# Altium schematic sheet 555TriangleGeneratorMB-Rev2b.SchDoc(555TriangleGeneratorMB-Rev2b)
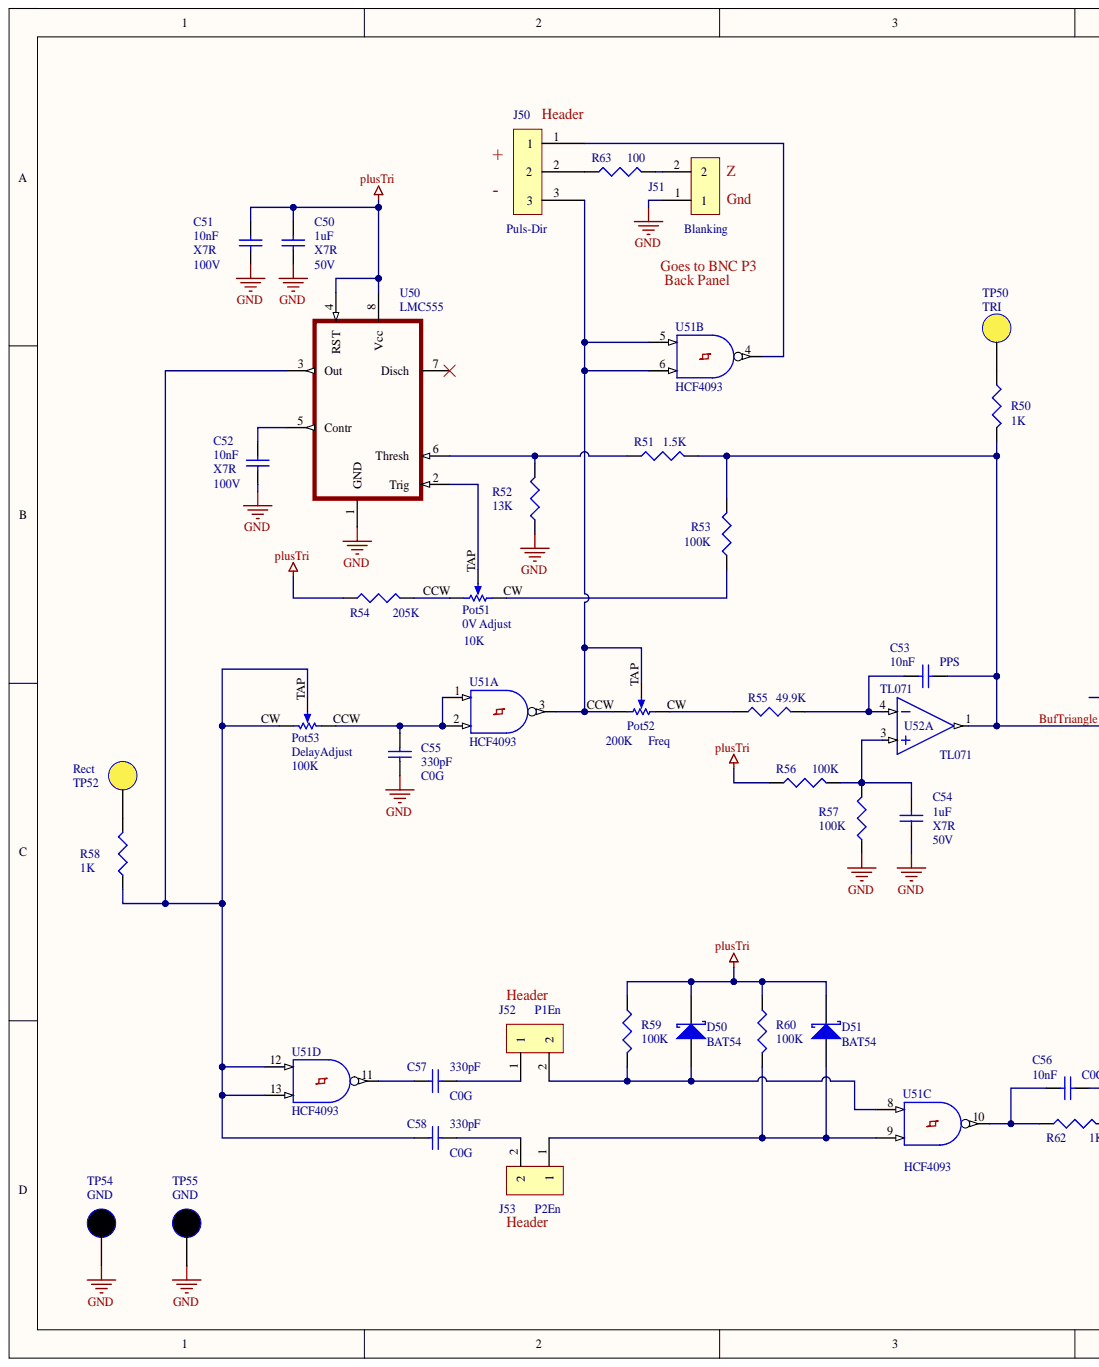
[diagram p0: 555TriangleGeneratorMB-Rev2b.SchDoc, left]
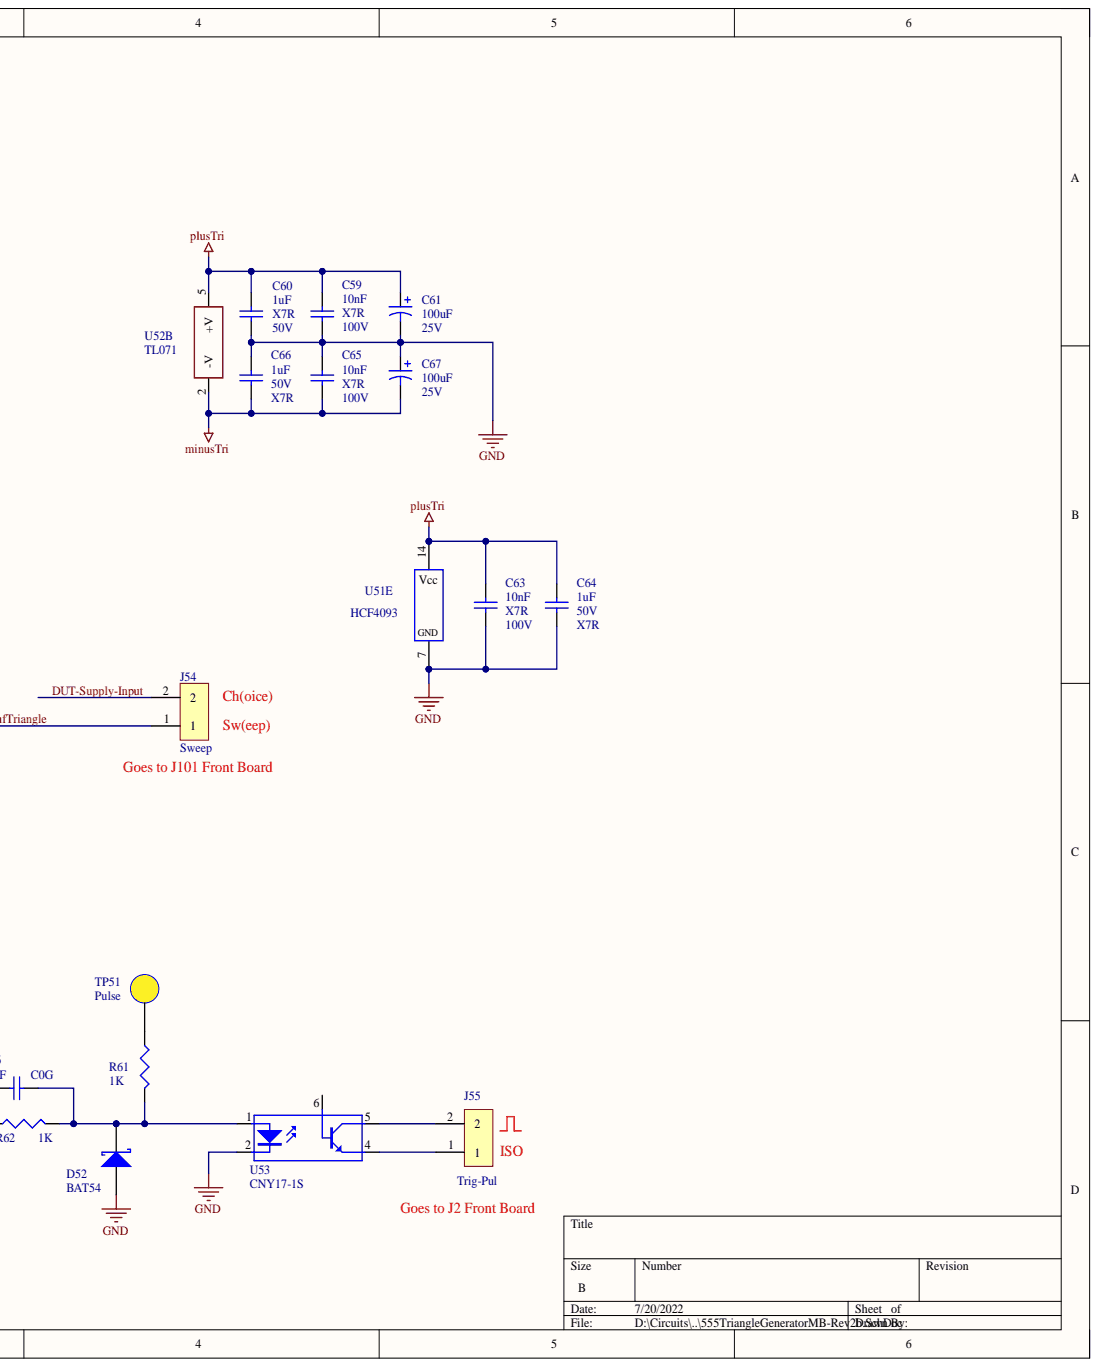
[diagram p1: 555TriangleGeneratorMB-Rev2b.SchDoc, right]

## Parts
C50  1uF  Dielectric: X7R  Voltage: 50V  [CAPC2012M]
C51  10nF  Dielectric: X7R  Voltage: 100V  [CAPC2012M]
C52  10nF  Dielectric: X7R  Voltage: 100V  [CAPC2012M]
C53  value 10nF  Capacitance: 10nF  Dielectric: PPS  [CAPC2012M]  (hidden comment: 1nF)
C54  1uF  Dielectric: X7R  Voltage: 50V  [CAPC2012M]
C55  330pF  Dielectric: C0G  [CAPC2012M]
C56  value 10nF  Capacitance: 10nF  Dielectric: C0G  [CAPC2012M]  (hidden comment: 1nF)
C57  330pF  Dielectric: C0G  [CAPC2012M]
C58  330pF  Dielectric: C0G  [CAPC2012M]
C59  10nF  Dielectric: X7R  Voltage: 100V  [CAPC2012M]
C60  1uF  Dielectric: X7R  Voltage: 50V  [CAPC2012M]
C61  100uF  Voltage: 25V  [CAPPR2-5X11]
C63  10nF  Dielectric: X7R  Voltage: 100V  [CAPC2012M]
C64  1uF  Dielectric: X7R  Voltage: 50V  [CAPC2012M]
C65  10nF  Dielectric: X7R  Voltage: 100V  [CAPC2012M]
C66  1uF  Dielectric: X7R  Voltage: 50V  [CAPC2012M]
C67  100uF  Voltage: 25V  [CAPPR2-5X11]
D50  BAT54  [SOD123]
D51  BAT54  [SOD123]
D52  BAT54  [SOD123]
J50  Puls-Dir  [HDR1X3]
J51  Blanking  [1988956]
J52  P1En  [2X1H0.1LS0.9MMHole]
J53  P2En  [2X1H0.1LS0.9MMHole]
J54  Sweep  [DTA-100-2]
J55  Trig-Pul  [DTA-100-2]
Pot51  0V Adjust  value 10K  [3306P-CCW]
Pot52  Freq  value 200K  [3306P-CCW]
Pot53  DelayAdjust  value 100K  [3306P-CCW]
R50  1K  [RESC2012M]
R51  1.5K  [RESC2012M]
R52  13K  [RESC2012M]
R53  100K  [RESC2012M]
R54  205K  [RESC2012M]
R55  49.9K  [RESC2012M]
R56  100K  [RESC2012M]
R57  100K  [RESC2012M]
R58  1K  [RESC2012M]
R59  100K  [RESC2012M]
R60  100K  [RESC2012M]
R61  1K  [RESC2012M]
R62  1K  [RESC2012M]
R63  100  [RESC2012M]
TP50  TRI  [TP-.038]
TP51  Pulse  [TP-.038]
TP52  Rect  [TP-.038]
TP54  GND  [TP-.038]
TP55  GND  [TP-.038]
U50  LMC555  [SOIC127P600X168_HS-8N]
U51  HCF4093  [SOIC127P600X14-M]  (parts A,B,C,D,E)
U52  TL071  [SOT95P300X130-5M]  (parts A,B)
U53  CNY-17-1S  value CNY17-1S  Manufacturer Part Number: CNY17-1S  [SOIC254P1000X6]

## Nets
GND: C50.2 C51.2 C52.1 C54.2 C55.2 C59.2 C60.2 C61.2 C63.2 C64.2 C65.2 C66.2 C67.1 D52.1 J51.1 R52.2 R57.1 TP54.1 TP55.1 U50.1(GND) U51.7 U53.2
minusTri: C65.1 C66.1 C67.2 U52.2(-V)
plusTri: C50.1 C51.1 C59.1 C60.1 C61.1 C63.1 C64.1 D50.2 D51.2 R54.1 R56.1 R59.1 R60.1 U50.4(RST) U50.8(Vcc) U51.14 U52.5(+V)
BufTriangle: C53.1 J54.1 R50.2 R51.1 R53.1 U52.1
DUT-Supply-Input: J54.2
NetC52_2: C52.2 U50.5(Contr)
NetC53_2: C53.2 R55.2 U52.4
NetC54_1: C54.1 R56.2 R57.2 U52.3
NetC55_1: C55.1 Pot53.CCW U51.1 U51.2
NetC56_1: C56.1 D52.2 R61.2 R62.2 U53.1
NetC56_2: C56.2 R62.1 U51.10
NetC57_1: C57.1 J52.1
NetC57_2: C57.2 U51.11
NetC58_1: C58.1 J53.2
NetC58_2: C58.2 Pot53.CW Pot53.TAP R58.1 U50.3(Out) U51.12 U51.13
NetD50_1: D50.1 J52.2 R59.2 U51.8
NetD51_1: D51.1 J53.1 R60.2 U51.9
NetJ50_1: J50.1 U51.4
NetJ50_2: J50.2 R63.1
NetJ50_3: J50.3 Pot52.CCW Pot52.TAP U51.3 U51.5 U51.6
NetJ51_2: J51.2 R63.2
NetJ55_1: J55.1 U53.4
NetJ55_2: J55.2 U53.5
NetPot51_CCW: Pot51.CCW R54.2
NetPot51_CW: Pot51.CW R53.2
NetPot51_TAP: Pot51.TAP U50.2(Trig)
NetPot52_CW: Pot52.CW R55.1
NetR50_1: R50.1 TP50.1
NetR51_2: R51.2 R52.1 U50.6(Thresh)
NetR58_2: R58.2 TP52.1
NetR61_1: R61.1 TP51.1

Not connected: U50 7(Disch); U53 6

## Library data of the parts
C50 C54 C60 C64 C66 = Cap: CAP CER 1UF 50V X7R 0805; Manufacturer: Samsung; Manufacturer Part Number: CL21B105KBFNNNE; Dielectric Material: Ceramic; Tolerance: 10%; Capacitance: 1uF; Case Code (Imperial): 0805; Case Code (Metric): 2012; Case/Package: 0805; Component Kind: Standard; Construction: Flat; Depth: 1.25mm; Height: 1.25mm; Length: 2mm; Library Name: Miscellaneous Devices.IntLib; Max Operating Temperature: 125°C; Min Operating Temperature: -55°C; Mount: Surface Mount; Number of Pins: 2; Package Quantity: 1; Packaging: Tape and Reel; Radiation Hardening: No; REACH SVHC: No SVHC; RoHS Compliant: Yes; Series: CL; Signal Integrity: Cap; Simulation: CAP; Supplier: Digi-Key; Supplier Part Number: 1276-1029-6-ND; Termination: SMD/SMT; Thickness: 0.053inch; Value: 1uF; Voltage Rating: 50V; Voltage Rating (DC): 50V; Width: 0.049inch
C51 C52 C59 C63 C65 = Cap: CAP CER 10NF 100V X7R 0805; Manufacturer: Samsung; Manufacturer Part Number: CL21B103KCANNNC; Dielectric Material: Ceramic; Dissipation Factor: 2.5%; Tolerance: 10%; Capacitance: 10nF; Case Code (Imperial): 0805; Case Code (Metric): 2012; Case/Package: 0805; Component Kind: Standard; Construction: Flat; Contact Plating: Tin; Depth: 1.25mm; Height: 0.65mm; Length: 2mm; Library Name: Miscellaneous Devices.IntLib; Material: Ceramic; Max Operating Temperature: 125°C; Min Operating Temperature: -55°C; Mount: Surface Mount; Number of Pins: 2; Package Quantity: 1; Packaging: Tape and Reel; Radiation Hardening: No; REACH SVHC: No SVHC; RoHS Compliant: Yes; Series: CL; Signal Integrity: Cap; Simulation: CAP; Supplier: Digi-Key; Supplier Part Number: 1276-1249-6-ND; Termination: SMD/SMT; Thickness: 0.03inch; Value: 10nF; Voltage Rating: 100V; Voltage Rating (DC): 100V; Width: 0.049inch
C53 = Cap: CAP FILM 10NF PPS16V; Manufacturer: Panasonic; Manufacturer Part Number: ECHU1C103JX5; Dielectric Material: Polyphenylene; Dissipation Factor: 0.6%; Insulation Resistance: 3GR; Life (Hours): 500Hour; Material: Ceramic; Tolerance: 5%; Voltage: 16V; Case Code (Imperial): 0805; Case Code (Metric): 2012; Case/Package: 0805; Category: Film Capacitors; Component Kind: Standard; ComponentLink1Description: https://datasheet.ciiva.com/11790/0900766b80eaa63b-11790974.pdf?src-supplier=RSComponents; ComponentLink1URL: https://datasheet.ciiva.com/11790/0900766b80eaa63b-11790974.pdf?src-supplier=RSComponents; ComponentLink2Description: https://datasheet.ciiva.com/46399/101575-46399306.pdf?src-supplier=Element14; ComponentLink2URL: https://datasheet.ciiva.com/46399/101575-46399306.pdf?src-supplier=Element14; ComponentLink3Description: https://datasheet.ciiva.com/14591/70067901-14591819.pdf?src-supplier=Allied+Electronics; ComponentLink3URL: https://datasheet.ciiva.com/14591/70067901-14591819.pdf?src-supplier=Allied+Electronics; ComponentLink4Description: https://datasheet.ciiva.com/16200/abd0000ce13-16200967.pdf?src-supplier=Future+Electronics; ComponentLink4URL: https://datasheet.ciiva.com/16200/abd0000ce13-16200967.pdf?src-supplier=Future+Electronics; ComponentLink5Description: https://datasheet.ciiva.com/30122/21abd0000ce13-30122759.pdf?src-supplier=Onlinecomponents.com; ComponentLink5URL: https://datasheet.ciiva.com/30122/21abd0000ce13-30122759.pdf?src-supplier=Onlinecomponents.com; Construction: Flat; Contact Plating: Copper,Tin,Silver; Depth: 1.25mm; Height: 1.1mm; Height - Seated (Max): 0.051inch; Lead Free: Lead Free; Length: 2mm; Library Name: Miscellaneous Devices.IntLib; Max Operating Temperature: 125°C; Min Operating Temperature: -55°C; Mount: Surface Mount; Number of Pins: 2; Package Quantity: 1; Packaging: Tape and Reel; Pricing 1: 1=0.44000, 10=0.31000, 50=0.23440, 100=0.20410, 500=0.15120, 1000=0.12852 (USD); Radiation Hardening: No; REACH SVHC: No SVHC; RoHS: Yes; RoHS Compliant: Yes; Series: ECHU(X); Signal Integrity: Cap; Simulation: CAP; Stock 1: 49221; Supplier: Digi-Key; Supplier Part Number: PCF1196CT-ND; Termination: SMD/SMT; Voltage Rating: 16V; Voltage Rating (DC): 16V; Width: 1.25mm
C55 C57 C58 = Cap: CAP CER 330PF 50V COG 0805; Manufacturer: KEMET; Manufacturer Part Number: C0805C331K5GACTU; Dielectric Material: Ceramic; Dissipation Factor: 0.1%; Tolerance: 10%; Capacitance: 330pF; Case Code (Imperial): 0805; Case Code (Metric): 2012; Case/Package: 0805; Component Kind: Standard; Depth: 1.25mm; Height: 0.78mm; Lead Free: Lead Free; Lead Pitch: 0.75mm; Length: 2mm; Library Name: Miscellaneous Devices.IntLib; Max Operating Temperature: 125°C; Min Operating Temperature: -55°C; Mount: Surface Mount; Package Quantity: 1; Packaging: Tape and Reel; Radiation Hardening: No; RoHS Compliant: Yes; Series: C; Signal Integrity: Cap; Simulation: CAP; Supplier: Digi-Key; Supplier Part Number: 399-8065-6-ND; Termination: SMD/SMT; Thickness: 0.035inch; Value: 330pF; Voltage Rating: 50V; Voltage Rating (DC): 50V; Width: 0.049inch
C56 = Cap: CAP CER 10NF 100V NP0 0805; Manufacturer: KEMET; Manufacturer Part Number: C0805C103J5GACTU; Dielectric Material: Ceramic; Dissipation Factor: 0.1%; Temperature Coefficient: 30ppm/°C; Tolerance: 5%; Voltage: 50V; Case Code (Imperial): 0805; Case Code (Metric): 2012; Case/Package: 0805; Component Kind: Standard; Construction: Flat; Contact Material: Tin; Contact Plating: Tin,Matte; Depth: 1.25mm; Height: 0.78mm; Lead Free: Lead Free; Lead Pitch: 0.75mm; Length: 2mm; Library Name: Miscellaneous Devices.IntLib; Max Operating Temperature: 125°C; Min Operating Temperature: -55°C; Mount: Surface Mount; Number of Pins: 2; Package Quantity: 1; Packaging: Tape and Reel; Radiation Hardening: No; REACH SVHC: No SVHC; RoHS Compliant: Yes; Series: C; Signal Integrity: Cap; Simulation: CAP; Supplier: Digi-Key; Supplier Part Number: 399-7992-6-ND; Termination: SMD/SMT; Thickness: 0.035inch; Voltage Rating: 50V; Voltage Rating (DC): 50V; Width: 0.049inch
C61 C67 = Cap Pol1: Aluminum Electrolytic Capacitors - Leaded 100uF ±20% 25V Radial, 5x11mm RoHS; Manufacturer: Rubycon; Manufacturer Part Number: 25YXJ100M5X11; Impedance: 400mR; Lead Diameter: 0.5mm; Leakage Current: 3uA; Life (Hours): 5000Hour; Polarity: Polar; Ripple Current: 250mA; Capacitance: 100uF; Case/Package: Radial; Category: Aluminum Electrolytic Capacitors; Component Kind: Standard; ComponentLink1Description: https://datasheet.ciiva.com/11777/0900766b810307a1-11777001.pdf?src-supplier=RSComponents; ComponentLink1URL: https://datasheet.ciiva.com/11777/0900766b810307a1-11777001.pdf?src-supplier=RSComponents; ComponentLink2Description: https://datasheet.ciiva.com/41806/e-yxj-41806041.pdf?src-supplier=Digi-Key; ComponentLink2URL: https://datasheet.ciiva.com/41806/e-yxj-41806041.pdf?src-supplier=Digi-Key; ComponentLink3Description: https://datasheet.ciiva.com/11690/e-yxj-11690904.pdf?src-supplier=Avnet; ComponentLink3URL: https://datasheet.ciiva.com/11690/e-yxj-11690904.pdf?src-supplier=Avnet; Construction: RADIAL; Diameter: 5mm; Height: 11mm; Height - Seated (Max): 0.492inch; Lead Pitch: 2mm; Library Name: Miscellaneous Devices.IntLib; Max Operating Temperature: 105°C; Min Operating Temperature: -40°C; Mount: Through Hole; Package Quantity: 3000; Packaging: Bulk; Pricing 1: 1=0.25000, 10=0.17200, 50=0.10360, 100=0.08740, 500=0.05980, 1000=0.05290, 2500=0.05060, 5000=0.04600 (USD); Radiation Hardening: No; RoHS: Yes; RoHS Compliant: Yes; Series: YXJ; Signal Integrity: Cap Pol; Simulation: CAP; Stock 1: 7789; Supplier: Digi-Key; Supplier Part Number: 1189-2237-ND; Termination: Radial; Tolerance: 20%; Value: 100uF; Voltage Rating: 25V
D50-D52 = Diode-Schottkey-12: SBAT54T1G Series 30 200 mA Surface Mount Schottky Barrier Diode - SOD-123; Manufacturer: ON Semiconductor; Average Rectified Current: 200mA; Element Configuration: Single; Forward Voltage: 520mV; Max Forward Surge Current (Ifsm): 600mA; Max Power Dissipation: 400mW; Max Repetitive Reverse Voltage (Vrrm): 30V; Max Reverse Current: 500nA; Reverse Recovery Time: 5ns; Category: Schottky Diodes; Component Kind: Standard; ComponentLink1Description: http://www.farnell.com/datasheets/1840528.pdf?src-supplier=Farnell; ComponentLink1URL: http://www.farnell.com/datasheets/1840528.pdf?src-supplier=Farnell; ComponentLink2Description: http://www.mouser.com/ds/2/308/BAT54T1-D-108887.pdf?src-supplier=Mouser; ComponentLink2URL: http://www.mouser.com/ds/2/308/BAT54T1-D-108887.pdf?src-supplier=Mouser; ComponentLink3Description: http://www.onsemi.com/pub/Collateral/BAT54T1-D.PDF?src-supplier=Digi-Key; ComponentLink3URL: http://www.onsemi.com/pub/Collateral/BAT54T1-D.PDF?src-supplier=Digi-Key; ComponentLink4Description: http://www.onsemi.com/pub/Collateral/BAT54T1-D.PDF?src-supplier=Avnet; ComponentLink4URL: http://www.onsemi.com/pub/Collateral/BAT54T1-D.PDF?src-supplier=Avnet; Forward Current: 200mA; Lead Free: Lead Free; Library Name: MY_Diodes.SchLib; Manufacturer Part Number: SBAT54T1G; Max Operating Temperature: 125°C; Max Reverse Voltage (DC): 30V; Min Operating Temperature: -55°C; Mount: Surface Mount; Package Quantity: 3000; Packaging: Tape and Reel; Pricing 1: 1=0.48700, 5=0.48700, 10=0.36400, 100=0.22100 (USD); Reverse Voltage (DC): 30V; RoHS: Yes; RoHS Compliant: Yes; Stock 1: 1490; Supplier: Newark; Supplier Part Number: 50AC7280; Value: BAT54
J50 = 3-Pin: HEADER VERT 3 POS 0.1LS; Manufacturer: CUI; Manufacturer Part Number: PH1-03-UA; Current Rating: 1A; Number of Positions: 3; Pitch: 2mm; Value: PH1-03-UA; Voltage Rating: 250V; Category: Connectors; Component Kind: Standard; ComponentLink1Description: https://datasheet.ciiva.com/16500/2ph1-xx-ua-16500546.pdf?src-supplier=Future+Electronics; ComponentLink1URL: https://datasheet.ciiva.com/16500/2ph1-xx-ua-16500546.pdf?src-supplier=Future+Electronics; ComponentLink2Description: https://datasheet.ciiva.com/16396/catpg240-254-16396026.pdf?src-supplier=Future+Electronics; ComponentLink2URL: https://datasheet.ciiva.com/16396/catpg240-254-16396026.pdf?src-supplier=Future+Electronics; Contact Gender: Male; Contact Material: Brass; Contact Plating: Gold; Housing Material: PBT; Lead Free: Lead Free; Library Name: MY_CONNECTORS.SchLib; Mount: Through Hole; Number of Rows: 1; Packaging: Bulk; Pricing 1: 1=0.13000, 10=0.10300, 100=0.07610, 500=0.06300, 1000=0.05512, 5000=0.04725, 10000=0.04594 (USD); Pricing 2: 1=0.10000, 10=0.05000, 100=0.03650, 500=0.03024, 1000=0.02646, 5000=0.02268, 10000=0.02205 (USD); RoHS: Yes; Stock 1: 76; Stock 2: 62000; Supplier: Digi-Key; Supplier 2: Digi-Key; Supplier Part Number: 2057-2PH1-03-UA-ND; Supplier Part Number 2: 2057-PH1-03-UA-ND; Termination: Solder
J51 = 2-Pin: Conn PC Terminal Block 2 POS 3.5mm Solder Thru-Hole 17.5A; Manufacturer: Phoenix Contact; Approvals: UL,EAC; Current: 10A; Gender: Male; Max Supply Voltage: 160V; Number of Circuits: 2; Number of Levels: 1; Value: 1988956; Voltage: 300V; Wire Gauge (Max): 26AWG; Wire Gauge (Min): 16AWG; Category: Terminal Blocks; Color: Green; Component Kind: Standard; ComponentLink1Description: https://datasheet.ciiva.com/709/eng-cd-640456-w1-709680.pdf?src-supplier=Digi-Key; ComponentLink1URL: https://datasheet.ciiva.com/709/eng-cd-640456-w1-709680.pdf?src-supplier=Digi-Key; ComponentLink2Description: https://datasheet.ciiva.com/3118/eng-ds-82056-mta-0608-3118497.pdf?src-supplier=Avnet; ComponentLink2URL: https://datasheet.ciiva.com/3118/eng-ds-82056-mta-0608-3118497.pdf?src-supplier=Avnet; ComponentLink3Description: https://datasheet.ciiva.com/16401/eng-ds-82056-mta-0608-16401289.pdf?src-supplier=Future+Electronics; ComponentLink3URL: https://datasheet.ciiva.com/16401/eng-ds-82056-mta-0608-16401289.pdf?src-supplier=Future+Electronics; ComponentLink4Description: https://datasheet.ciiva.com/25617/0900766b80027008-25617244.pdf?src-supplier=RSComponents; ComponentLink4URL: https://datasheet.ciiva.com/25617/0900766b80027008-25617244.pdf?src-supplier=RSComponents; ComponentLink5Description: https://datasheet.ciiva.com/14156/04384301-14156820.pdf?src-supplier=Distrelec; ComponentLink5URL: https://datasheet.ciiva.com/14156/04384301-14156820.pdf?src-supplier=Distrelec; ComponentLink6Description: https://datasheet.ciiva.com/11746/0900766b80eb7fdd-11746365.pdf?src-supplier=RSComponents; ComponentLink6URL: https://datasheet.ciiva.com/11746/0900766b80eb7fdd-11746365.pdf?src-supplier=RSComponents; ComponentLink7Description: https://datasheet.ciiva.com/7128/1866390-7128742.pdf?src-supplier=Newark; ComponentLink7URL: https://datasheet.ciiva.com/7128/1866390-7128742.pdf?src-supplier=Newark; ComponentLink8Description: https://datasheet.ciiva.com/16505/eng-ds-82056-mta-0608-16505419.pdf?src-supplier=Avnet+Asia; ComponentLink8URL: https://datasheet.ciiva.com/16505/eng-ds-82056-mta-0608-16505419.pdf?src-supplier=Avnet+Asia; ComponentLink9Description: https://datasheet.ciiva.com/18620/1713017-18620870.pdf?src-supplier=Digi-Key; ComponentLink9URL: https://datasheet.ciiva.com/18620/1713017-18620870.pdf?src-supplier=Digi-Key; ComponentLink10Description: https://datasheet.ciiva.com/16047/1989748-16047438.pdf?src-supplier=Onlinecomponents.com; ComponentLink10URL: https://datasheet.ciiva.com/16047/1989748-16047438.pdf?src-supplier=Onlinecomponents.com; ComponentLink11Description: https://datasheet.ciiva.com/11758/0900766b811d404e-11758587.pdf?src-supplier=RSComponents; ComponentLink11URL: https://datasheet.ciiva.com/11758/0900766b811d404e-11758587.pdf?src-supplier=RSComponents; ComponentLink12Description: https://datasheet.ciiva.com/6746/combiconspring-cagepcbterm-blocks-6746175.pdf?src-supplier=Digi-Key; ComponentLink12URL: https://datasheet.ciiva.com/6746/combiconspring-cagepcbterm-blocks-6746175.pdf?src-supplier=Digi-Key; ComponentLink13Description: https://datasheet.ciiva.com/14607/70055059-14607702.pdf?src-supplier=Allied+Electronics; ComponentLink13URL: https://datasheet.ciiva.com/14607/70055059-14607702.pdf?src-supplier=Allied+Electronics; ComponentLink14Description: https://datasheet.ciiva.com/16051/1988956-16051359.pdf?src-supplier=Onlinecomponents.com; ComponentLink14URL: https://datasheet.ciiva.com/16051/1988956-16051359.pdf?src-supplier=Onlinecomponents.com; ComponentLink15Description: https://datasheet.ciiva.com/26340/567541-da-01-de-printklemme-1988956-250-st-26340451.pdf?src-supplier=Conrad; ComponentLink15URL: https://datasheet.ciiva.com/26340/567541-da-01-de-printklemme-1988956-250-st-26340451.pdf?src-supplier=Conrad; ComponentLink16Description: https://datasheet.ciiva.com/824/combiconcompactseries-824224.pdf?src-supplier=Digi-Key; ComponentLink16URL: https://datasheet.ciiva.com/824/combiconcompactseries-824224.pdf?src-supplier=Digi-Key; ComponentLink17Description: https://datasheet.ciiva.com/14609/70055171-14609100.pdf?src-supplier=Allied+Electronics; ComponentLink17URL: https://datasheet.ciiva.com/14609/70055171-14609100.pdf?src-supplier=Allied+Electronics; Contact Material: Tin; Current Rating: 17.5A; Depth: 11.2mm; Flammability Rating: UL94 V-0; Height: 11.2mm; Housing Color: Green; Housing Material: Polyamide; Lead Free: Lead Free; Length: 7mm; Library Name: MY_CONNECTORS.SchLib; Manufacturer Part Number: 1988956; Material: Polyamide; Max Current Rating: 17.5A; Mount: Through Hole; Number of Contacts: 2; Number of Pins: 2; Number of Poles: 2; Number of Positions: 2; Number of Positions Per Level: 2; Number of Rows: 1; Orientation: Straight; Package Quantity: 250; Packaging: Bulk; Pitch: 3.5mm; Pricing 1: 1=0.55000, 10=0.52900, 50=0.50600, 100=0.49220, 250=0.46000, 500=0.43792 (USD); RoHS: Yes; RoHS Compliant: Yes; Series: COMBICON PTA; Stock 1: 7961; Supplier: Digi-Key; Supplier Part Number: 277-1779-ND; Termination: Screw; Voltage Rating: 160V; Weight: 0.034216oz; Width: 13.7mm
J52 J53 = 2-Pin: HEADER VERT 2 POS 0.1LS; Manufacturer: Adam Teck; Manufacturer Part Number: PH1-02-UA; Connector Type: Male Pin; Current Rating: 3A; Number of Positions: 2; Pitch: 0.1inch; Value: PH1-02-UA; Voltage Rating: 250V; Category: Connectors; Color: Black; Component Kind: Standard; ComponentLink1Description: https://datasheet.ciiva.com/5160/page-292-295-12946-5160830.pdf?src-supplier=Mouser; ComponentLink1URL: https://datasheet.ciiva.com/5160/page-292-295-12946-5160830.pdf?src-supplier=Mouser; ComponentLink2Description: https://datasheet.ciiva.com/16392/ph1-xx-ua-16392701.pdf?src-supplier=Future+Electronics; ComponentLink2URL: https://datasheet.ciiva.com/16392/ph1-xx-ua-16392701.pdf?src-supplier=Future+Electronics; Contact Gender: Male; Contact Material: Brass; Contact Mating Length: 0.23inch; Contact Plating: Tin; Contact Type: Male Pin; Housing Material: PBT; Lead Free: Lead Free; Library Name: MY_CONNECTORS.SchLib; Mount: Through Hole; Number of Rows: 1; Package Quantity: 1; Packaging: Bulk; RoHS: Yes; RoHS Compliant: Yes; Supplier: Digi-Key; Supplier Part Number: 2057-PH1-02-UA-ND; Termination: Solder
J54 J55 = 2-Pin: 2.54mm 2pin; Manufacturer: TE Connectivity; Manufacturer Part Number: 640456-2; Approvals: CSA; Backwall/Post Interruptions: No (Without); Circuit Application: Signal; Connector System: Wire-to-Board; Connector Type: Connector,Pin,Plug,Header; Contact Current Rating: 5A; Contact Post Length: 3.56mm; Contact Resistance: 6mR; Contact Style: Straight; Gender: Male; Mating Connector Lock: Yes (With); Max Voltage Rating (AC): 250V; Number of Contacts: 2; PCB Mount Retention: Without; PCB Mounting Orientation: Vertical; PCB Thickness: 0.063inch; Plating Thickness: 3.81um; Tail Length: 0.14inch; Value: 640456-2; Category: Headers and Wire Housings; Color: Natural,White,Beige; Component Kind: Standard; ComponentLink1Description: https://datasheet.ciiva.com/709/eng-cd-640456-w1-709680.pdf?src-supplier=Digi-Key; ComponentLink1URL: https://datasheet.ciiva.com/709/eng-cd-640456-w1-709680.pdf?src-supplier=Digi-Key; ComponentLink2Description: https://datasheet.ciiva.com/3118/eng-ds-82056-mta-0608-3118497.pdf?src-supplier=Avnet; ComponentLink2URL: https://datasheet.ciiva.com/3118/eng-ds-82056-mta-0608-3118497.pdf?src-supplier=Avnet; ComponentLink3Description: https://datasheet.ciiva.com/16401/eng-ds-82056-mta-0608-16401289.pdf?src-supplier=Future+Electronics; ComponentLink3URL: https://datasheet.ciiva.com/16401/eng-ds-82056-mta-0608-16401289.pdf?src-supplier=Future+Electronics; ComponentLink4Description: https://datasheet.ciiva.com/25617/0900766b80027008-25617244.pdf?src-supplier=RSComponents; ComponentLink4URL: https://datasheet.ciiva.com/25617/0900766b80027008-25617244.pdf?src-supplier=RSComponents; ComponentLink5Description: https://datasheet.ciiva.com/14156/04384301-14156820.pdf?src-supplier=Distrelec; ComponentLink5URL: https://datasheet.ciiva.com/14156/04384301-14156820.pdf?src-supplier=Distrelec; ComponentLink6Description: https://datasheet.ciiva.com/11746/0900766b80eb7fdd-11746365.pdf?src-supplier=RSComponents; ComponentLink6URL: https://datasheet.ciiva.com/11746/0900766b80eb7fdd-11746365.pdf?src-supplier=RSComponents; ComponentLink7Description: https://datasheet.ciiva.com/7128/1866390-7128742.pdf?src-supplier=Newark; ComponentLink7URL: https://datasheet.ciiva.com/7128/1866390-7128742.pdf?src-supplier=Newark; ComponentLink8Description: https://datasheet.ciiva.com/16505/eng-ds-82056-mta-0608-16505419.pdf?src-supplier=Avnet+Asia; ComponentLink8URL: https://datasheet.ciiva.com/16505/eng-ds-82056-mta-0608-16505419.pdf?src-supplier=Avnet+Asia; ComponentLink9Description: https://datasheet.ciiva.com/18620/1713017-18620870.pdf?src-supplier=Digi-Key; ComponentLink9URL: https://datasheet.ciiva.com/18620/1713017-18620870.pdf?src-supplier=Digi-Key; ComponentLink10Description: https://datasheet.ciiva.com/16047/1989748-16047438.pdf?src-supplier=Onlinecomponents.com; ComponentLink10URL: https://datasheet.ciiva.com/16047/1989748-16047438.pdf?src-supplier=Onlinecomponents.com; ComponentLink11Description: https://datasheet.ciiva.com/11758/0900766b811d404e-11758587.pdf?src-supplier=RSComponents; ComponentLink11URL: https://datasheet.ciiva.com/11758/0900766b811d404e-11758587.pdf?src-supplier=RSComponents; ComponentLink12Description: https://datasheet.ciiva.com/6746/combiconspring-cagepcbterm-blocks-6746175.pdf?src-supplier=Digi-Key; ComponentLink12URL: https://datasheet.ciiva.com/6746/combiconspring-cagepcbterm-blocks-6746175.pdf?src-supplier=Digi-Key; ComponentLink13Description: https://datasheet.ciiva.com/14607/70055059-14607702.pdf?src-supplier=Allied+Electronics; ComponentLink13URL: https://datasheet.ciiva.com/14607/70055059-14607702.pdf?src-supplier=Allied+Electronics; ComponentLink14Description: https://datasheet.ciiva.com/16051/1988956-16051359.pdf?src-supplier=Onlinecomponents.com; ComponentLink14URL: https://datasheet.ciiva.com/16051/1988956-16051359.pdf?src-supplier=Onlinecomponents.com; ComponentLink15Description: https://datasheet.ciiva.com/26340/567541-da-01-de-printklemme-1988956-250-st-26340451.pdf?src-supplier=Conrad; ComponentLink15URL: https://datasheet.ciiva.com/26340/567541-da-01-de-printklemme-1988956-250-st-26340451.pdf?src-supplier=Conrad; ComponentLink16Description: https://datasheet.ciiva.com/824/combiconcompactseries-824224.pdf?src-supplier=Digi-Key; ComponentLink16URL: https://datasheet.ciiva.com/824/combiconcompactseries-824224.pdf?src-supplier=Digi-Key; ComponentLink17Description: https://datasheet.ciiva.com/14609/70055171-14609100.pdf?src-supplier=Allied+Electronics; ComponentLink17URL: https://datasheet.ciiva.com/14609/70055171-14609100.pdf?src-supplier=Allied+Electronics; Contact Gender: Male; Contact Material: Copper Alloy; Contact Mating Length: 7.49mm; Contact Plating: Tin; Contact Retention: No (Without); Contact Shape: Square; Contact Type: Male Pin; Current Rating: 5A; Depth: 5.72mm; ELV: Compliant; Fastening Type: Friction; Flammability Rating: UL94 V-0; Height: 7.87mm; Housing Color: Natural; Housing Material: Polyester; Lead Free: Lead Free; Lead Pitch: 2.54mm; Length: 7.62mm; Library Name: MY_CONNECTORS.SchLib; Mating Alignment: No (Without); Mating Retention: No (Without); Max Operating Temperature: 221°C; Min Operating Temperature: -67°C; Mount: Through Hole; Mounting Ears: No (Without); Number of Poles: 2; Number of Positions: 2; Number of Rows: 1; Orientation: Straight; Package Quantity: 5000; Packaging: Bulk; Panel Mount Retention: No (Without); PCB Mount Alignment: No (Without); Pitch: 2.54mm; Pricing 1: 1=0.13000, 10=0.10400, 100=0.07700, 500=0.06374, 1000=0.05578, 5000=0.04781, 10000=0.04648 (USD); Radiation Hardening: No; REACH SVHC: No SVHC; RoHS: Yes; RoHS Compliant: Yes; Sealable: No (Without); Series: MTA-100; Shrouded: No (Without); Stock 1: 18986; Strain Relief: No (Without); Supplier: Digi-Key; Supplier Part Number: A1921-ND; Termination: Solder; Voltage Rating: 250V; Voltage Rating (AC): 250V; Working Voltage: 250V
Pot51 = POT-Single: TRIMMER 10K OHM 0.2W TH; Manufacturer: Bourns; Manufacturer Part Number: 3306P-1-103; Lead Diameter: 1mm; Max Power Dissipation: 200mW; Number of Turns: 1; Pitch: 2.5mm; Resistance: 10kR; Temperature Coefficient: 250ppm/°C; Tolerance: 25%; Voltage Rating: 100V; Color: Blue; Colour: Blue; Component Kind: Standard; Composition: Cermet; Depth: 8.1mm; Diameter: 6.81mm; Height: 7.62mm; Lead Free: Lead Free; Length: 6.81mm; Max Operating Temperature: 100°C; Military Standard: Not; Min Operating Temperature: -25°C; Mount: Through Hole,PCB; Number of Terminals: 3; Package Quantity: 200; Packaging: Bulk; Power Rating: 200mW; Radiation Hardening: No; REACH SVHC: No SVHC; Supplier: Digi-Key; Supplier Part Number: 3306P-103-ND; Taper: Linear; Termination: Pin; Type: Potentiometer; Voltage Rating (DC): 100V; Weight: 360mg; Width: 6.81mm; Library Name: MY_POTS.SchLib
Pot52 = POT-Single: TRIMMER 200K OHM 0.2W TH; Manufacturer: Bourns; Manufacturer Part Number: 3306P-1-204; Lead Diameter: 1mm; Max Power Dissipation: 200mW; Number of Turns: 1; Pitch: 2.5mm; Resistance: 200kR; Temperature Coefficient: 250ppm/°C; Tolerance: 25%; Voltage Rating: 100V; Color: Blue; Colour: Blue; Component Kind: Standard; Composition: Cermet; Depth: 8.1mm; Diameter: 6.81mm; Height: 7.62mm; Lead Free: Lead Free; Length: 6.81mm; Max Operating Temperature: 100°C; Military Standard: Not; Min Operating Temperature: -25°C; Mount: Through Hole,PCB; Number of Terminals: 3; Package Quantity: 200; Packaging: Bulk; Power Rating: 200mW; Radiation Hardening: No; REACH SVHC: No SVHC; Supplier: Digi-Key; Supplier Part Number: 3306P-204-ND; Taper: Linear; Termination: Pin; Type: Potentiometer; Voltage Rating (DC): 100V; Weight: 360mg; Width: 6.81mm; Library Name: MY_POTS.SchLib
Pot53 = POT-Single: TRIMMER 100K OHM 0.2W TH; Manufacturer: Bourns; Manufacturer Part Number: 3306P-1-104; Lead Diameter: 1mm; Max Power Dissipation: 200mW; Number of Turns: 1; Pitch: 2.5mm; Resistance: 100kR; Temperature Coefficient: 250ppm/°C; Tolerance: 25%; Voltage Rating: 100V; Color: Blue; Colour: Blue; Component Kind: Standard; Composition: Cermet; Depth: 8.1mm; Diameter: 6.81mm; Height: 7.62mm; Lead Free: Lead Free; Length: 6.81mm; Max Operating Temperature: 100°C; Military Standard: Not; Min Operating Temperature: -25°C; Mount: Through Hole,PCB; Number of Terminals: 3; Package Quantity: 200; Packaging: Bulk; Power Rating: 200mW; Radiation Hardening: No; REACH SVHC: No SVHC; Supplier: Digi-Key; Supplier Part Number: 3306P-104-ND; Taper: Linear; Termination: Pin; Type: Potentiometer; Voltage Rating (DC): 100V; Weight: 360mg; Width: 6.81mm; Library Name: MY_POTS.SchLib
R50 R58 R61 R62 = Res3: RES 1K OHM 1% 1/4W 0805; Manufacturer: KOA Speer; Manufacturer Part Number: RK73H2ATTD1001F; Max Power Dissipation: 250mW; Power Rating: 125mW; Resistance: 1kR; Temperature Coefficient: 100ppm/°C; Voltage Rating: 150V; Case Code (Imperial): 0805; Case Code (Metric): 2012; Case/Package: 0805; Component Kind: Standard; Composition: Thick Film; Construction: Rectangular; Contact Plating: Matte,Tin; Depth: 1.25mm; Features: Automotive AEC-Q200; Height: 0.5mm; Lead Free: Lead Free; Length: 2mm; Library Name: Miscellaneous Devices.IntLib; Max Operating Temperature: 155°C; Military Standard: Not; Min Operating Temperature: -55°C; Mount: Surface Mount; Number of Pins: 2; Number of Terminations: 2; Package Quantity: 5000; Packaging: Tape and Reel; Radiation Hardening: No; REACH SVHC: Unknown; Resistance Tolerance: 1%; Resistor Type: Thick Film; RoHS Compliant: Yes; Series: RK73H; Signal Integrity: Res; Simulation: RESISTOR; Supplier: Digi-Key; Supplier Part Number: 2019-RK73H2ATTD1001FDKR-ND; Termination: SMD/SMT; Tolerance: 1%; Value: 1K; Voltage Rating (DC): 150V; Width: 1.25mm
R51 = Res3: RES 1.5K OHM 1% 1/4W 0805; Manufacturer: KOA Speer; Manufacturer Part Number: RK73H2ATTD1501F; Power Rating: 125mW; Resistance: 1.5kR; Temperature Coefficient: 100ppm/°C; Voltage Rating: 150V; Case Code (Imperial): 0805; Case Code (Metric): 2012; Case/Package: 0805; Component Kind: Standard; Construction: Rectangular; Depth: 1.25mm; Height: 0.5mm; Lead Free: Lead Free; Length: 2mm; Library Name: Miscellaneous Devices.IntLib; Max Operating Temperature: 155°C; Military Standard: Not; Min Operating Temperature: -55°C; Number of Pins: 2; Package Quantity: 5000; Packaging: Tape and Reel; Resistance Tolerance: 1%; Resistor Type: Thick Film; RoHS Compliant: Yes; Series: RK73H; Signal Integrity: Res; Simulation: RESISTOR; Supplier: Digi-Key; Supplier Part Number: 2019-RK73H2ATTD1501FCT-ND; Termination: SMD/SMT; Tolerance: 1%; Value: 1.5K; Width: 1.25mm
R52 = Res3: RES 13K OHM 1% 1/4W 0805; Manufacturer: KOA Speer; Manufacturer Part Number: RK73H2ATTD1302F; Max Power Dissipation: 250mW; Power Rating: 125mW; Resistance: 13kR; Temperature Coefficient: 100ppm/°C; Voltage Rating: 150V; Case Code (Imperial): 0805; Case Code (Metric): 2012; Case/Package: 0805; Component Kind: Standard; Composition: Thick Film; Construction: Rectangular; Contact Plating: Matte,Tin; Depth: 1.25mm; Features: Automotive AEC-Q200; Height: 0.5mm; Lead Free: Lead Free; Length: 2mm; Library Name: Miscellaneous Devices.IntLib; Max Operating Temperature: 155°C; Military Standard: Not; Min Operating Temperature: -55°C; Mount: Surface Mount; Number of Pins: 2; Number of Terminations: 2; Package Quantity: 5000; Packaging: Tape and Reel; Radiation Hardening: No; REACH SVHC: Unknown; Resistance Tolerance: 1%; Resistor Type: Thick Film; Series: RK73H; Signal Integrity: Res; Simulation: RESISTOR; Supplier: Digi-Key; Supplier Part Number: 2019-RK73H2ATTD1302FDKR-ND; Termination: SMD/SMT; Tolerance: 1%; Value: 13K; Voltage Rating (DC): 150V; Width: 1.25mm
R53 = Res3: RES 100K OHM 1% 1/4W 0805; Manufacturer: KOA Speer; Manufacturer Part Number: RK73H2ATTD1003F; Max Power Dissipation: 250mW; Power Rating: 125mW; Resistance: 100kR; Temperature Coefficient: 100ppm/°C; Voltage Rating: 150V; Case Code (Imperial): 0805; Case Code (Metric): 2012; Case/Package: 0805; Component Kind: Standard; Composition: Thick Film; Construction: Rectangular; Contact Plating: Matte,Tin; Depth: 1.25mm; Features: Automotive AEC-Q200; Height: 0.5mm; Lead Free: Lead Free; Length: 2mm; Library Name: Miscellaneous Devices.IntLib; Max Operating Temperature: 155°C; Military Standard: Not; Min Operating Temperature: -55°C; Mount: Surface Mount; Number of Pins: 2; Number of Terminations: 2; Package Quantity: 5000; Packaging: Tape and Reel; Radiation Hardening: No; REACH SVHC: Unknown; Resistance Tolerance: 1%; Resistor Type: Thick Film; Series: RK73H; Signal Integrity: Res; Simulation: RESISTOR; Supplier: Digi-Key; Supplier Part Number: 2019-RK73H2ATTD1003FDKR-ND; Termination: SMD/SMT; Tolerance: 1%; Value: 100K; Voltage Rating (DC): 150V; Width: 1.25mm
R54 = Res3: RES 205K OHM 1% 1/4W 0805; Manufacturer: KOA Speer; Manufacturer Part Number: RK73H2ATTD2053F; Power Rating: 125mW; Resistance: 205kR; Temperature Coefficient: 100ppm/°C; Voltage Rating: 150V; Case Code (Imperial): 0805; Case Code (Metric): 2012; Case/Package: 0805; Component Kind: Standard; Construction: Rectangular; Depth: 1.25mm; Height: 0.5mm; Lead Free: Lead Free; Length: 2mm; Library Name: Miscellaneous Devices.IntLib; Max Operating Temperature: 155°C; Military Standard: Not; Min Operating Temperature: -55°C; Number of Pins: 2; Package Quantity: 5000; Packaging: Tape and Reel; REACH SVHC: Unknown; Resistance Tolerance: 1%; Resistor Type: Thick Film; RoHS Compliant: Yes; Series: RK73H; Signal Integrity: Res; Simulation: RESISTOR; Supplier: Digi-Key; Supplier Part Number: 2019-RK73H2ATTD2053FDKR-ND; Termination: SMD/SMT; Tolerance: 1%; Value: 205K; Voltage Rating (DC): 150V; Width: 1.25mm
R55 = Res3: RES 49.9K OHM 1% 1/4W 0805; Manufacturer: KOA Speer; Manufacturer Part Number: RK73H2ATTD4992F; Power Rating: 125mW; Resistance: 49.9kR; Temperature Coefficient: 100ppm/°C; Voltage Rating: 150V; Case Code (Imperial): 0805; Case Code (Metric): 2012; Case/Package: 0805; Component Kind: Standard; Construction: Rectangular; Depth: 1.25mm; Height: 0.5mm; Lead Free: Lead Free; Length: 2mm; Library Name: Miscellaneous Devices.IntLib; Max Operating Temperature: 155°C; Military Standard: Not; Min Operating Temperature: -55°C; Number of Pins: 2; Package Quantity: 5000; Packaging: Tape and Reel; REACH SVHC: Unknown; Resistance Tolerance: 1%; Resistor Type: Thick Film; RoHS Compliant: Yes; Series: RK73H; Signal Integrity: Res; Simulation: RESISTOR; Supplier: Digi-Key; Supplier Part Number: 2019-RK73H2ATTD4992FDKR-ND; Termination: SMD/SMT; Tolerance: 1%; Value: 49.9K; Voltage Rating (DC): 150V; Width: 1.25mm
R56 R57 R59 R60 = Res3: RES 100K OHM 1% 1/4W 0805; Manufacturer: KOA Speer; Manufacturer Part Number: RK73H2ATTD1003F; Power Rating: 125mW; Resistance: 100kR; Temperature Coefficient: 100ppm/°C; Voltage Rating: 150V; Case Code (Imperial): 0805; Case Code (Metric): 2012; Case/Package: 0805; Component Kind: Standard; Construction: Rectangular; Depth: 1.25mm; Height: 0.5mm; Lead Free: Lead Free; Length: 2mm; Library Name: Miscellaneous Devices.IntLib; Max Operating Temperature: 155°C; Military Standard: Not; Min Operating Temperature: -55°C; Number of Pins: 2; Package Quantity: 5000; Packaging: Tape and Reel; REACH SVHC: Unknown; Resistance Tolerance: 1%; Resistor Type: Thick Film; RoHS Compliant: Yes; Series: RK73H; Signal Integrity: Res; Simulation: RESISTOR; Supplier: Digi-Key; Supplier Part Number: 2019-RK73H2ATTD1003FDKR-ND; Termination: SMD/SMT; Tolerance: 1%; Value: 100K; Voltage Rating (DC): 150V; Width: 1.25mm
R63 = Res3: RES 100 OHM 1% 1/4W 0805; Manufacturer: KOA Speer; Manufacturer Part Number: RK73H2ATTD1000F; Max Power Dissipation: 250mW; Power Rating: 125mW; Resistance: 100R; Temperature Coefficient: 100ppm/°C; Voltage Rating: 150V; Case Code (Imperial): 0805; Case Code (Metric): 2012; Case/Package: 0805; Component Kind: Standard; Composition: Thick Film; Construction: Rectangular; Contact Plating: Matte,Tin; Depth: 1.25mm; Features: Automotive AEC-Q200; Height: 0.5mm; Lead Free: Lead Free; Length: 2mm; Library Name: Miscellaneous Devices.IntLib; Max Operating Temperature: 155°C; Military Standard: Not; Min Operating Temperature: -55°C; Mount: Surface Mount; Number of Pins: 2; Number of Terminations: 2; Package Quantity: 5000; Packaging: Tape and Reel; Radiation Hardening: No; REACH SVHC: Unknown; Resistance Tolerance: 1%; Resistor Type: Thick Film; RoHS Compliant: Yes; Series: RK73H; Signal Integrity: Res; Simulation: RESISTOR; Supplier: Digi-Key; Supplier Part Number: 2019-RK73H2ATTD1000FDKR-ND; Technology: THICK FILM; Termination: SMD/SMT; Tolerance: 1%; Value: 100; Voltage Rating (DC): 150V; Width: 1.25mm
TP50-TP52 = TP-Round-Yellow: TP Thru-Hole; Manufacturer: UW; Manufacturer Part Number: Gold; Component Kind: Standard; Library Name: MY_TP.SchLib; Supplier: UW; Supplier Part Number: Gold; Value: TP Thru-Hole
TP54 TP55 = TP-Round-Black: TP Thru-Hole; Manufacturer: UW; Manufacturer Part Number: Gold; Component Kind: Standard; Library Name: MY_TP.SchLib; Supplier: UW; Supplier Part Number: Gold; Value: TP Thru-Hole
U50 = LM555: IC OSC SINGLE TIMER 3MHZ 8-SOIC; Manufacturer: Texas Instruments; Manufacturer Part Number: LMC555CMX/NOPB; Current - Supply: 150µA; Voltage - Supply: 1.5 V ~ 15 V; Category: Integrated Circuits (ICs); Component Kind: Standard; Frequency: 3MHz; Library Name: MY_National.SchLib; Mounting Type: Surface Mount; Operating Temperature: -40°C ~ 85°C; Package / Case: 8-SOIC (0.154", 3.90mm Width); Packaging: Cut Tape (CT); Part Status: Active; RoHS: RoHS Compliant; Supplier: Digi-Key; Supplier Device Package: 8-SOIC; Supplier Part Number: LMC555CMX/NOPBCT-ND; Type: 555 Type, Timer/Oscillator (Single); Value: LMC555
U51 = CD4093: Quad NAND w/Schmitt trigger; Manufacturer: STMicroelectronics; Manufacturer Part Number: HCF4093YM013TR; High Level Output Current: 6.8mA; Logic Function: NAND GATE; Max Power Dissipation: 200mW; Max Supply Voltage: 20V; Min Supply Voltage: 3V; Number of Circuits: 4; Number of Input Lines: 2; Number of Outputs: 1; Propagation Delay: 130ns; Quiescent Current: 20uA; Case/Package: SOIC; Component Kind: Standard; Lead Free: Lead Free; Library Name: MY_Logic Gate.SchLib; Low Level Output Current: 6.8mA; Max Operating Temperature: 125°C; Min Operating Temperature: -40°C; Mount: Surface Mount; Number of Elements: 4; Number of Gates: 4; Number of Inputs: 2; Number of Output Lines: 2; Number of Pins: 14; Package Quantity: 2500; Packaging: Tape and Reel; RoHS Compliant: Yes; Supplier: Digi-Key; Supplier Part Number: 497-14376-6-ND; Value: HCF4093; Weight: 0.009143oz
U52 = Single Op-Amp 5pin: Single, 40-V, 5-MHz, 4-mV offset voltage, 20-V/µs, In to V+ op amp with -40°C to 125°C operation 5-SOT-23 -40 to 125; Manufacturer: Texas Instruments; Manufacturer Part Number: TL071HIDBVR; Component Kind: Standard; Library Name: My_OpAmps.SchLib; Pricing 1: 1=0.45000, 10=0.36900, 25=0.33720, 100=0.25100, 250=0.22748, 500=0.18826, 1000=0.14119 (USD); RoHS: No; Stock 1: 10511; Supplier: Digi-Key; Supplier Part Number: 296-TL071HIDBVRCT-ND; Value: TL071
U53 = CYN17: OPTOISO 5KV TRANS W/BASE 6SMD; Manufacturer: Vishay Lite-On; Collector Emitter Saturation Voltage: 300mV; Collector Emitter Voltage (VCEO): 70V; Current Transfer Ratio: 80%; Fall Time: 10000ns; Forward Current: 60mA; Forward Voltage: 1.45V; Max Collector Current: 150mA; Max Power Dissipation: 250mW; Number of Channels: 1; Reverse Breakdown Voltage: 6V; Case/Package: SMD/SMT; Component Kind: Standard; Lead Free: Lead Free; Library Name: MY_Opto.SchLib; Max Input Current: 60mA; Max Operating Temperature: 100°C; Max Output Voltage: 70V; Min Operating Temperature: -55°C; Mount: Surface Mount; Number of Circuits: 1; Number of Elements: 1; Number of Pins: 6; Output Current per Channel: 150mA; Output Voltage: 70V; Package Quantity: 65; Packaging: Rail/Tube; Power Dissipation: 250mW; Rise Time: 10000ns; Supplier: Digi-Key; Supplier Part Number: 160-1309-5-ND
